# Altium schematic sheet board_sheet7.SchDoc
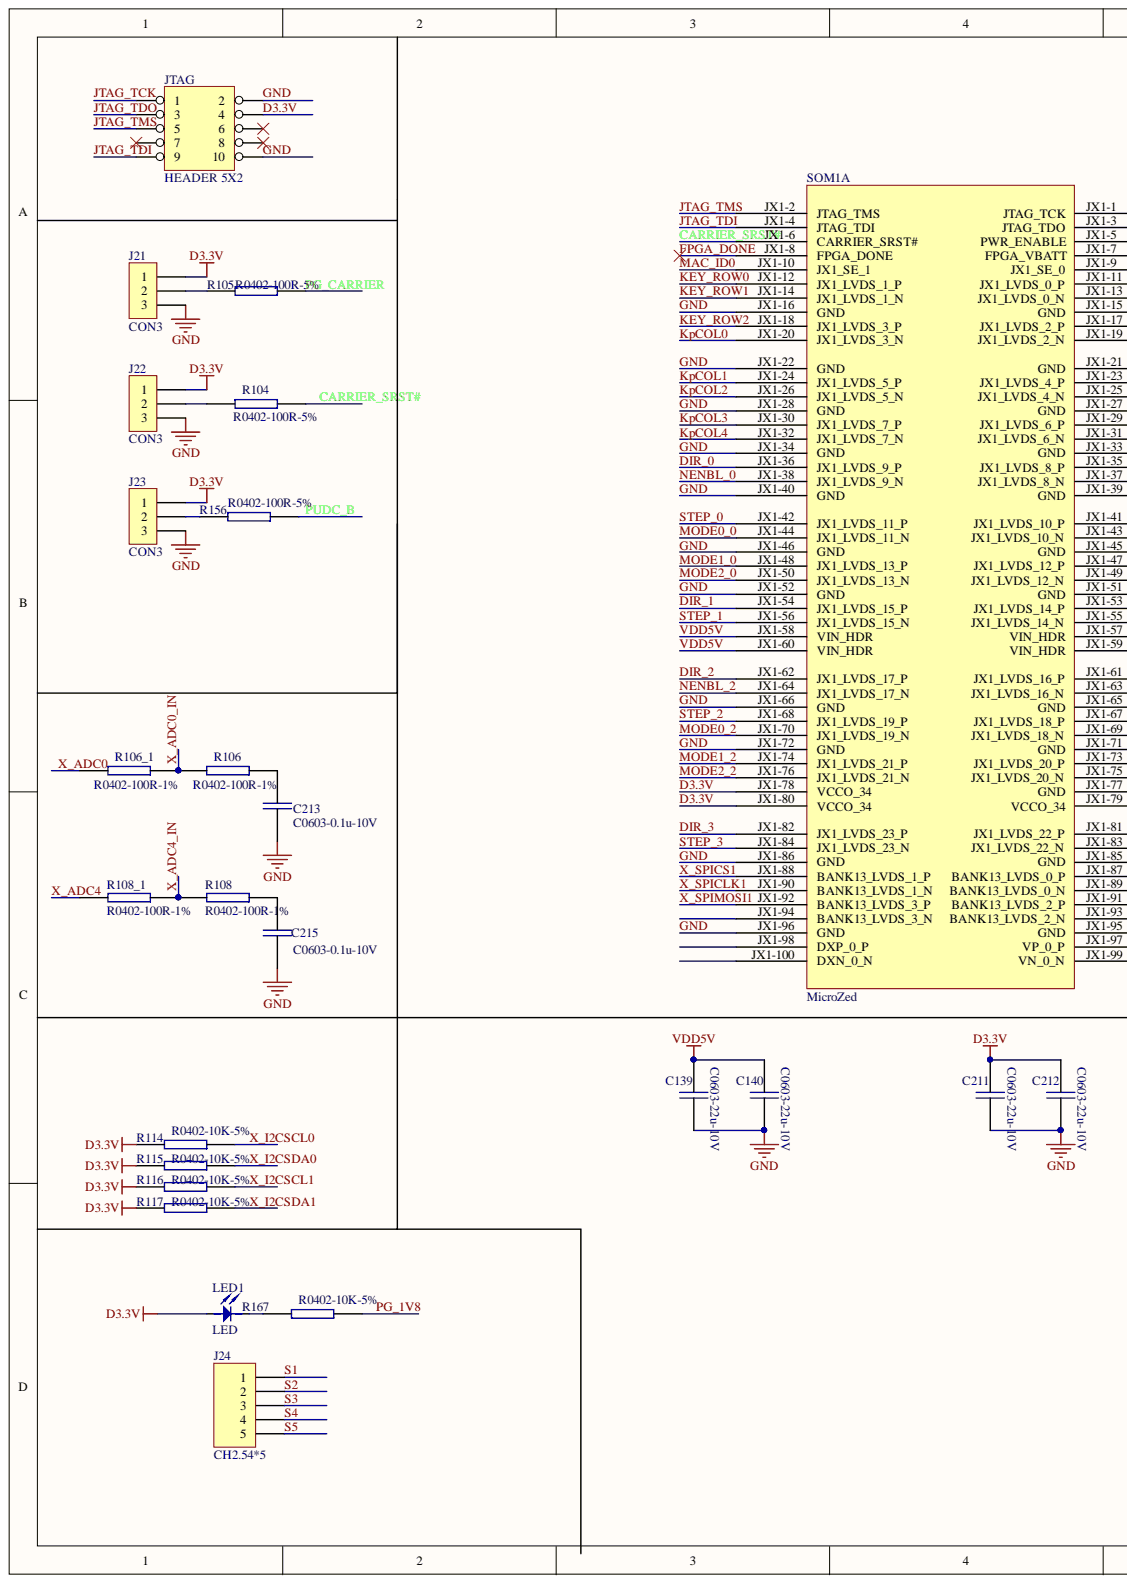
[diagram p0: board_sheet7.SchDoc, left]
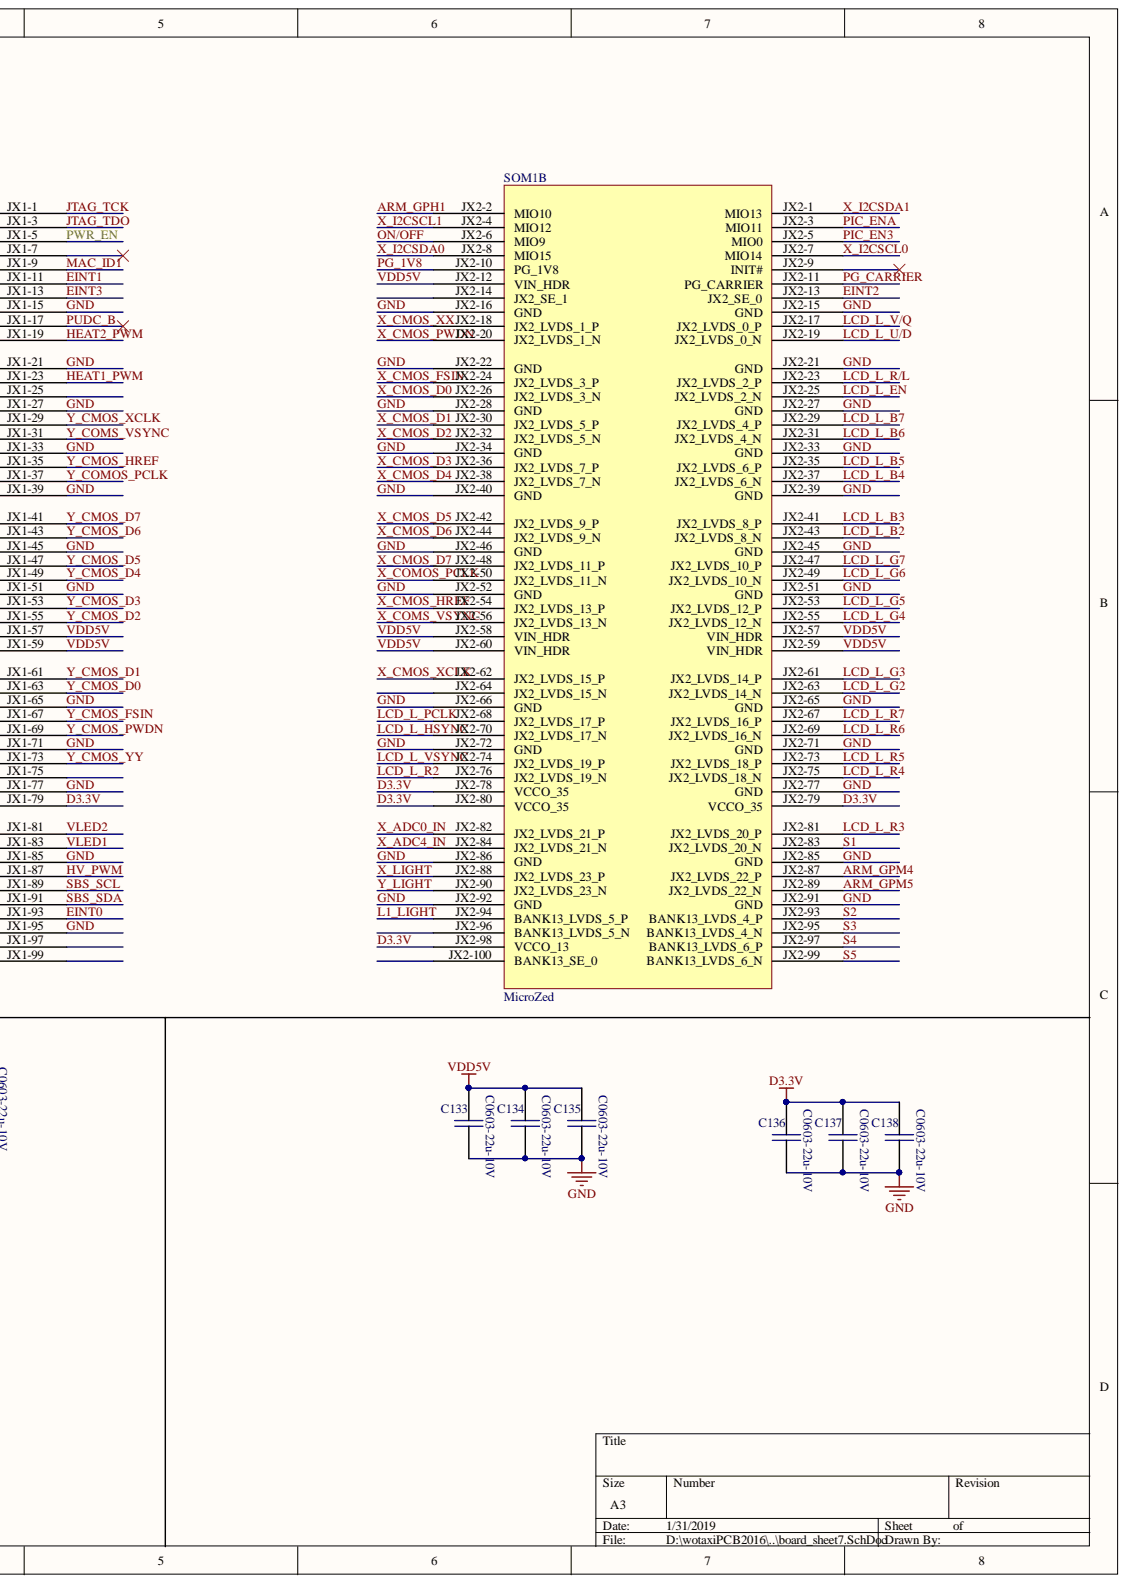
[diagram p1: board_sheet7.SchDoc, right]

## Parts
C133  C0603-22u-10V  [C_0603]
C134  C0603-22u-10V  [C_0603]
C135  C0603-22u-10V  [C_0603]
C136  C0603-22u-10V  [C_0603]
C137  C0603-22u-10V  [C_0603]
C138  C0603-22u-10V  [C_0603]
C139  C0603-22u-10V  [C_0603]
C140  C0603-22u-10V  [C_0603]
C211  C0603-22u-10V  [C_0603]
C212  C0603-22u-10V  [C_0603]
C213  C0603-0.1u-10V  [C_0603]
C215  C0603-0.1u-10V  [C_0603]
J21  CON3  [SIP03]
J22  CON3  [SIP03]
J23  CON3  [SIP03]
J24  CH2.54*5  [HD1.25*5]
JTAG  HEADER 5X2  [IDC10]
LED1  LED  [LED]
R104  R0402-100R-5%  [0402]
R105  R0402-100R-5%  [0402]
R106  R0402-100R-1%  [0402]
R106_1  R0402-100R-1%  [0402]
R108  R0402-100R-1%  [0402]
R108_1  R0402-100R-1%  [0402]
R114  R0402-10K-5%  [0402]
R115  R0402-10K-5%  [0402]
R116  R0402-10K-5%  [0402]
R117  R0402-10K-5%  [0402]
R156  R0402-100R-5%  [0402]
R167  R0402-10K-5%  [0402]
SOM1  MicroZed  [MicroZedSOM]  (parts A,B)

## Nets
ARM_GPH1: SOM1.JX2-2(MIO10)
ARM_GPM4: SOM1.JX2-87(JX2_LVDS_22_P)
ARM_GPM5: SOM1.JX2-89(JX2_LVDS_22_N)
CARRIER_SRST#: R104.1 SOM1.JX1-6(CARRIER_SRST#)
D3.3V: C136.1 C137.1 C138.1 C211.1 C212.1 J21.1 J22.1 J23.1 JTAG.4 LED1.A R114.1 R115.1 R116.1 R117.1 SOM1.JX1-78(VCCO_34) SOM1.JX1-79(VCCO_34) SOM1.JX1-80(VCCO_34) SOM1.JX2-78(VCCO_35) SOM1.JX2-79(VCCO_35) SOM1.JX2-80(VCCO_35) SOM1.JX2-98(VCCO_13)
DIR_0: SOM1.JX1-36(JX1_LVDS_9_P)
DIR_1: SOM1.JX1-54(JX1_LVDS_15_P)
DIR_2: SOM1.JX1-62(JX1_LVDS_17_P)
DIR_3: SOM1.JX1-82(JX1_LVDS_23_P)
EINT0: SOM1.JX1-93(BANK13_LVDS_2_N)
EINT1: SOM1.JX1-11(JX1_LVDS_0_P)
EINT2: SOM1.JX2-13(JX2_SE_0)
EINT3: SOM1.JX1-13(JX1_LVDS_0_N)
FPGA_DONE: SOM1.JX1-8(FPGA_DONE)
GND: C133.2 C134.2 C135.2 C136.2 C137.2 C138.2 C139.2 C140.2 C211.2 C212.2 C213.1 C215.1 J21.3 J22.3 J23.3 JTAG.2 JTAG.10 SOM1.JX1-15(GND) SOM1.JX1-16(GND) SOM1.JX1-21(GND) SOM1.JX1-22(GND) SOM1.JX1-27(GND) SOM1.JX1-28(GND) SOM1.JX1-33(GND) SOM1.JX1-34(GND) SOM1.JX1-39(GND) SOM1.JX1-40(GND) SOM1.JX1-45(GND) SOM1.JX1-46(GND) SOM1.JX1-51(GND) SOM1.JX1-52(GND) SOM1.JX1-65(GND) SOM1.JX1-66(GND) SOM1.JX1-71(GND) SOM1.JX1-72(GND) SOM1.JX1-77(GND) SOM1.JX1-85(GND) SOM1.JX1-86(GND) SOM1.JX1-95(GND) SOM1.JX1-96(GND) SOM1.JX2-15(GND) SOM1.JX2-16(GND) SOM1.JX2-21(GND) SOM1.JX2-22(GND) SOM1.JX2-27(GND) SOM1.JX2-28(GND) SOM1.JX2-33(GND) SOM1.JX2-34(GND) SOM1.JX2-39(GND) SOM1.JX2-40(GND) SOM1.JX2-45(GND) SOM1.JX2-46(GND) SOM1.JX2-51(GND) SOM1.JX2-52(GND) SOM1.JX2-65(GND) SOM1.JX2-66(GND) SOM1.JX2-71(GND) SOM1.JX2-72(GND) SOM1.JX2-77(GND) SOM1.JX2-85(GND) SOM1.JX2-86(GND) SOM1.JX2-91(GND) SOM1.JX2-92(GND)
HEAT1_PWM: SOM1.JX1-23(JX1_LVDS_4_P)
HEAT2_PWM: SOM1.JX1-19(JX1_LVDS_2_N)
HV_PWM: SOM1.JX1-87(BANK13_LVDS_0_P)
JTAG_TCK: JTAG.1 SOM1.JX1-1(JTAG_TCK)
JTAG_TDI: JTAG.9 SOM1.JX1-4(JTAG_TDI)
JTAG_TDO: JTAG.3 SOM1.JX1-3(JTAG_TDO)
JTAG_TMS: JTAG.5 SOM1.JX1-2(JTAG_TMS)
KEY_ROW0: SOM1.JX1-12(JX1_LVDS_1_P)
KEY_ROW1: SOM1.JX1-14(JX1_LVDS_1_N)
KEY_ROW2: SOM1.JX1-18(JX1_LVDS_3_P)
KpCOL0: SOM1.JX1-20(JX1_LVDS_3_N)
KpCOL1: SOM1.JX1-24(JX1_LVDS_5_P)
KpCOL2: SOM1.JX1-26(JX1_LVDS_5_N)
KpCOL3: SOM1.JX1-30(JX1_LVDS_7_P)
KpCOL4: SOM1.JX1-32(JX1_LVDS_7_N)
L1_LIGHT: SOM1.JX2-94(BANK13_LVDS_5_P)
LCD_L_B2: SOM1.JX2-43(JX2_LVDS_8_N)
LCD_L_B3: SOM1.JX2-41(JX2_LVDS_8_P)
LCD_L_B4: SOM1.JX2-37(JX2_LVDS_6_N)
LCD_L_B5: SOM1.JX2-35(JX2_LVDS_6_P)
LCD_L_B6: SOM1.JX2-31(JX2_LVDS_4_N)
LCD_L_B7: SOM1.JX2-29(JX2_LVDS_4_P)
LCD_L_EN: SOM1.JX2-25(JX2_LVDS_2_N)
LCD_L_G2: SOM1.JX2-63(JX2_LVDS_14_N)
LCD_L_G3: SOM1.JX2-61(JX2_LVDS_14_P)
LCD_L_G4: SOM1.JX2-55(JX2_LVDS_12_N)
LCD_L_G5: SOM1.JX2-53(JX2_LVDS_12_P)
LCD_L_G6: SOM1.JX2-49(JX2_LVDS_10_N)
LCD_L_G7: SOM1.JX2-47(JX2_LVDS_10_P)
LCD_L_HSYNC: SOM1.JX2-70(JX2_LVDS_17_N)
LCD_L_PCLK: SOM1.JX2-68(JX2_LVDS_17_P)
LCD_L_R2: SOM1.JX2-76(JX2_LVDS_19_N)
LCD_L_R3: SOM1.JX2-81(JX2_LVDS_20_P)
LCD_L_R4: SOM1.JX2-75(JX2_LVDS_18_N)
LCD_L_R5: SOM1.JX2-73(JX2_LVDS_18_P)
LCD_L_R6: SOM1.JX2-69(JX2_LVDS_16_N)
LCD_L_R7: SOM1.JX2-67(JX2_LVDS_16_P)
LCD_L_R/L: SOM1.JX2-23(JX2_LVDS_2_P)
LCD_L_U/D: SOM1.JX2-19(JX2_LVDS_0_N)
LCD_L_V/Q: SOM1.JX2-17(JX2_LVDS_0_P)
LCD_L_VSYNC: SOM1.JX2-74(JX2_LVDS_19_P)
MAC_ID0: SOM1.JX1-10(JX1_SE_1)
MAC_ID1: SOM1.JX1-9(JX1_SE_0)
MODE0_0: SOM1.JX1-44(JX1_LVDS_11_N)
MODE0_2: SOM1.JX1-70(JX1_LVDS_19_N)
MODE1_0: SOM1.JX1-48(JX1_LVDS_13_P)
MODE1_2: SOM1.JX1-74(JX1_LVDS_21_P)
MODE2_0: SOM1.JX1-50(JX1_LVDS_13_N)
MODE2_2: SOM1.JX1-76(JX1_LVDS_21_N)
NENBL_0: SOM1.JX1-38(JX1_LVDS_9_N)
NENBL_2: SOM1.JX1-64(JX1_LVDS_17_N)
ON/OFF: SOM1.JX2-6(MIO9)
PG_1V8: R167.2 SOM1.JX2-10(PG_1V8)
PG_CARRIER: R105.2 SOM1.JX2-11(PG_CARRIER)
PIC_EN3: SOM1.JX2-5(MIO0)
PIC_ENA: SOM1.JX2-3(MIO11)
PUDC_B: R156.2 SOM1.JX1-17(JX1_LVDS_2_P)
PWR_EN: SOM1.JX1-5(PWR_ENABLE)
S1: J24.1 SOM1.JX2-83(JX2_LVDS_20_N)
S2: J24.2 SOM1.JX2-93(BANK13_LVDS_4_P)
S3: J24.3 SOM1.JX2-95(BANK13_LVDS_4_N)
S4: J24.4 SOM1.JX2-97(BANK13_LVDS_6_P)
S5: J24.5 SOM1.JX2-99(BANK13_LVDS_6_N)
SBS_SCL: SOM1.JX1-89(BANK13_LVDS_0_N)
SBS_SDA: SOM1.JX1-91(BANK13_LVDS_2_P)
STEP_0: SOM1.JX1-42(JX1_LVDS_11_P)
STEP_1: SOM1.JX1-56(JX1_LVDS_15_N)
STEP_2: SOM1.JX1-68(JX1_LVDS_19_P)
STEP_3: SOM1.JX1-84(JX1_LVDS_23_N)
VDD5V: C133.1 C134.1 C135.1 C139.1 C140.1 SOM1.JX1-57(VIN_HDR) SOM1.JX1-58(VIN_HDR) SOM1.JX1-59(VIN_HDR) SOM1.JX1-60(VIN_HDR) SOM1.JX2-12(VIN_HDR) SOM1.JX2-57(VIN_HDR) SOM1.JX2-58(VIN_HDR) SOM1.JX2-59(VIN_HDR) SOM1.JX2-60(VIN_HDR)
VLED1: SOM1.JX1-83(JX1_LVDS_22_N)
VLED2: SOM1.JX1-81(JX1_LVDS_22_P)
X_ADC0: R106_1.1
X_ADC0_IN: R106.1 R106_1.2 SOM1.JX2-82(JX2_LVDS_21_P)
X_ADC4: R108_1.1
X_ADC4_IN: R108.1 R108_1.2 SOM1.JX2-84(JX2_LVDS_21_N)
X_CMOS_D0: SOM1.JX2-26(JX2_LVDS_3_N)
X_CMOS_D1: SOM1.JX2-30(JX2_LVDS_5_P)
X_CMOS_D2: SOM1.JX2-32(JX2_LVDS_5_N)
X_CMOS_D3: SOM1.JX2-36(JX2_LVDS_7_P)
X_CMOS_D4: SOM1.JX2-38(JX2_LVDS_7_N)
X_CMOS_D5: SOM1.JX2-42(JX2_LVDS_9_P)
X_CMOS_D6: SOM1.JX2-44(JX2_LVDS_9_N)
X_CMOS_D7: SOM1.JX2-48(JX2_LVDS_11_P)
X_CMOS_FSIN: SOM1.JX2-24(JX2_LVDS_3_P)
X_CMOS_HREF: SOM1.JX2-54(JX2_LVDS_13_P)
X_CMOS_PWDN: SOM1.JX2-20(JX2_LVDS_1_N)
X_CMOS_XCLK: SOM1.JX2-62(JX2_LVDS_15_P)
X_CMOS_XX: SOM1.JX2-18(JX2_LVDS_1_P)
X_COMOS_PCLK: SOM1.JX2-50(JX2_LVDS_11_N)
X_COMS_VSYNC: SOM1.JX2-56(JX2_LVDS_13_N)
X_I2CSCL0: R114.2 SOM1.JX2-7(MIO14)
X_I2CSCL1: R116.2 SOM1.JX2-4(MIO12)
X_I2CSDA0: R115.2 SOM1.JX2-8(MIO15)
X_I2CSDA1: R117.2 SOM1.JX2-1(MIO13)
X_LIGHT: SOM1.JX2-88(JX2_LVDS_23_P)
X_SPICLK1: SOM1.JX1-90(BANK13_LVDS_1_N)
X_SPICS1: SOM1.JX1-88(BANK13_LVDS_1_P)
X_SPIMOSI1: SOM1.JX1-92(BANK13_LVDS_3_P)
Y_CMOS_D0: SOM1.JX1-63(JX1_LVDS_16_N)
Y_CMOS_D1: SOM1.JX1-61(JX1_LVDS_16_P)
Y_CMOS_D2: SOM1.JX1-55(JX1_LVDS_14_N)
Y_CMOS_D3: SOM1.JX1-53(JX1_LVDS_14_P)
Y_CMOS_D4: SOM1.JX1-49(JX1_LVDS_12_N)
Y_CMOS_D5: SOM1.JX1-47(JX1_LVDS_12_P)
Y_CMOS_D6: SOM1.JX1-43(JX1_LVDS_10_N)
Y_CMOS_D7: SOM1.JX1-41(JX1_LVDS_10_P)
Y_CMOS_FSIN: SOM1.JX1-67(JX1_LVDS_18_P)
Y_CMOS_HREF: SOM1.JX1-35(JX1_LVDS_8_P)
Y_CMOS_PWDN: SOM1.JX1-69(JX1_LVDS_18_N)
Y_CMOS_XCLK: SOM1.JX1-29(JX1_LVDS_6_P)
Y_CMOS_YY: SOM1.JX1-73(JX1_LVDS_20_P)
Y_COMOS_PCLK: SOM1.JX1-37(JX1_LVDS_8_N)
Y_COMS_VSYNC: SOM1.JX1-31(JX1_LVDS_6_N)
Y_LIGHT: SOM1.JX2-90(JX2_LVDS_23_N)
NetC213_2: C213.2 R106.2
NetC215_2: C215.2 R108.2
NetJ21_2: J21.2 R105.1
NetJ22_2: J22.2 R104.2
NetJ23_2: J23.2 R156.1
NetLED1_K: LED1.K R167.1

Not connected: JTAG 6 7 8; SOM1 JX1-7(FPGA_VBATT) JX1-25(JX1_LVDS_4_N) JX1-75(JX1_LVDS_20_N) JX1-94(BANK13_LVDS_3_N) JX1-97(VP_0_P) JX1-98(DXP_0_P) JX1-99(VN_0_N) JX1-100(DXN_0_N) JX2-9(INIT#) JX2-14(JX2_SE_1) JX2-64(JX2_LVDS_15_N) JX2-96(BANK13_LVDS_5_N) JX2-100(BANK13_SE_0)

## Library data of the parts
C133-C140 C211-C213 C215 = CAP: Component Kind: Standard; Library Name: KL300-POWER.SCHLIB
J24 = MHDR1X5: Component Kind: Standard; Library Name: KL300-POWER.SCHLIB
R104-R106 R106_1 R108 R108_1 R114-R117 R156 R167 = RES: Component Kind: Standard; Library Name: KL300-CONTROLER.SCHLIB
SOM1 = MicroZed_SOM: MicroZed SOM Carrier Footprint; Component Kind: Standard; Library Name: 140320.SchLib
J21-J23 = CON3: Component Kind: Standard; Library Name: 常用元件库.SCHLIB
JTAG = HEADER 5X2: Component Kind: Standard; Library Name: Documents.IntLib
LED1 = LED: Component Kind: Standard; Library Name: 常用元件库.SCHLIB
